# Altium schematic sheet PowerDistr.SchDoc(PowerDistr)
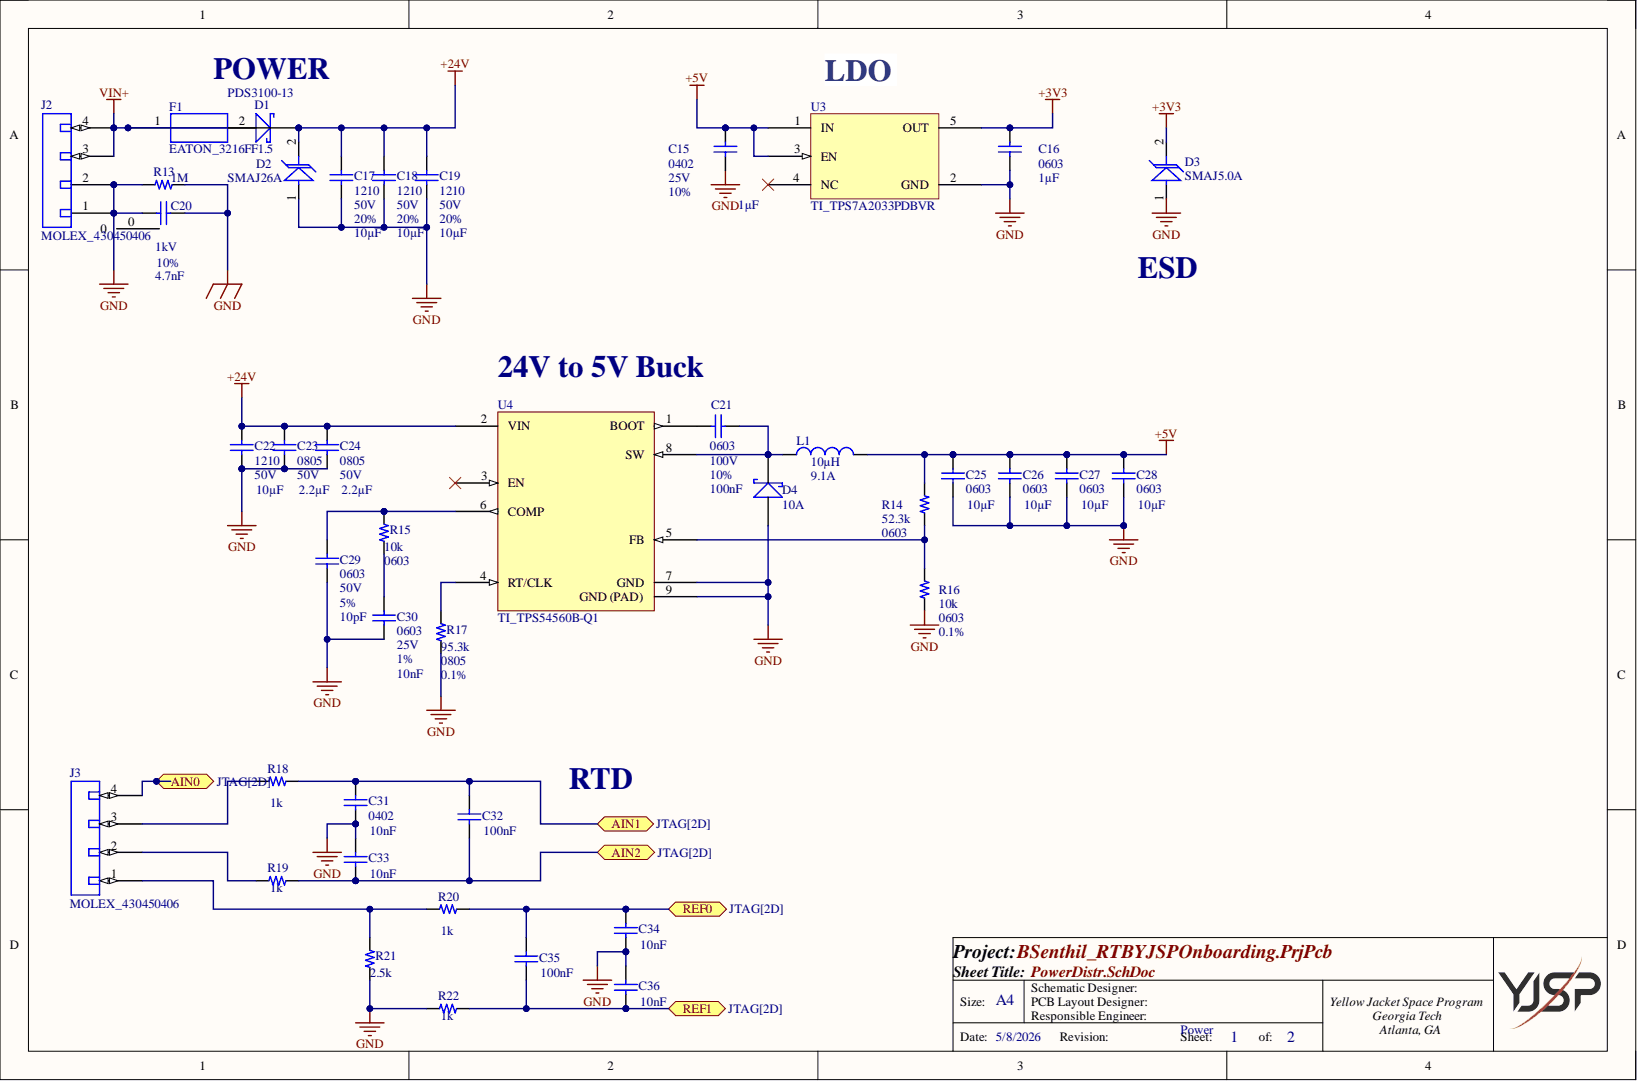
[diagram p0: PowerDistr.SchDoc]

## Parts
C15  Package: 0402  Tolerance: 10%  Voltage Rating: 25V  (hidden comment: GRM155C81E105KE11D)
C16  Capacitance: 1µF  Package: 0603  (hidden comment: CGA3E1X7R1E105M080AE)
C17  Package: 1210  Tolerance: 20%  Voltage Rating: 50V  (hidden comment: C3225X7R1H106M250AC)
C18  Package: 1210  Tolerance: 20%  Voltage Rating: 50V  (hidden comment: C3225X7R1H106M250AC)
C19  Package: 1210  Tolerance: 20%  Voltage Rating: 50V  (hidden comment: C3225X7R1H106M250AC)
C20  0805Y1K00472KST  (value not drawn)
C21  Package: 0603  (hidden comment: CL10B104KC8NNNC)
C22  Capacitance: 10µF  Package: 1210  Voltage Rating: 50V  (hidden comment: C3225X7R1H106M250AC)
C23  Capacitance: 2.2µF  Package: 0805  Voltage Rating: 50V  (hidden comment: CL21A225KBFNNNE)
C24  Capacitance: 2.2µF  Package: 0805  Voltage Rating: 50V  (hidden comment: CL21A225KBFNNNE)
C25  Capacitance: 10µF  Package: 0603  (hidden comment: GRM188R61E106MA73D)
C26  Capacitance: 10µF  Package: 0603  (hidden comment: GRM188R61E106MA73D)
C27  Capacitance: 10µF  Package: 0603  (hidden comment: GRM188R61E106MA73D)
C28  Capacitance: 10µF  Package: 0603  (hidden comment: GRM188R61E106MA73D)
C29  Package: 0603  Tolerance: 5%  Voltage Rating: 50V  (hidden comment: GRM1885C1H100JA01D)
C30  Package: 0603  Tolerance: 1%  Voltage Rating: 25V  (hidden comment: GRT1885C1E103FA02D)
C31  Capacitance: 10nF  Package: 0402  (hidden comment: GCM155R71H103KA55D)
C32  Capacitance: 100nF  (hidden comment: GCM155R71H104KE02J)
C33  Capacitance: 10nF  (hidden comment: GCM155R71H103KA55D)
C34  Capacitance: 10nF  (hidden comment: GCM155R71H103KA55D)
C35  Capacitance: 100nF  (hidden comment: GCM155R71H104KE02J)
C36  Capacitance: 10nF  (hidden comment: GCM155R71H103KA55D)
D1  PDS3100-13
D2  SMAJ26A
D3  SMAJ5.0A
D4  10A
F1  EATON_3216FF1.5
J2  MOLEX_430450406
J3  MOLEX_430450406
L1  Current Rating: 9.1A  Inductance: 10µH
R13  Resistance: 1M
R14  Package: 0603  Resistance: 52.3k
R15  Package: 0603  Resistance: 10k
R16  Package: 0603  Resistance: 10k  Tolerance: 0.1%
R17  Package: 0805  Resistance: 95.3k  Tolerance: 0.1%
R18  Resistance: 1k
R19  Resistance: 1k
R20  Resistance: 1k
R21  Resistance: 2.5k
R22  Resistance: 1k
U3  TI_TPS7A2033PDBVR
U4  TI_TPS54560B-Q1

## Ports
AIN0, AIN1, AIN2, REF0, REF1

## Nets
+3V3: C16.2 D3.2 U3.5(OUT)
+5V: C15.2 C25.2 C26.2 C27.2 C28.2 L1.2 R14.2 U3.1(IN) U3.3(EN)
+24V: C17.2 C18.2 C19.2 C22.2 C23.2 C24.2 D1.2 D2.2 U4.2(VIN)
GND: C15.1 C16.1 C17.1 C18.1 C19.1 C20.1 C20.2 C22.1 C23.1 C24.1 C25.1 C26.1 C27.1 C28.1 C29.1 C30.1 C31.1 C33.2 C34.1 C36.2 D2.1 D3.1 D4.1 J2.1 J2.2 R13.1 R13.2 R16.1 R17.1 R21.1 R22.1 U3.2(GND) U4.7(GND) U4.9(GND_(PAD))
VIN+: D1.1 F1.1 J2.3 J2.4
AIN0: J3.4 ; port AIN0
AIN1: C31.2 C32.2 R18.2 ; port AIN1
AIN2: C32.1 C33.1 R19.2 ; port AIN2
REF0: C34.2 C35.2 R20.2 ; port REF0
REF1: C35.1 C36.1 R22.2 ; port REF1
NetC21_1: C21.1 U4.1(BOOT)
NetC21_2: C21.2 D4.2 L1.1 U4.8(SW)
NetC29_2: C29.2 R15.2 U4.6(COMP)
NetC30_2: C30.2 R15.1
NetJ3_1: J3.1 R20.1 R21.2
NetJ3_2: J3.2 R19.1
NetJ3_3: J3.3 R18.1
NetR14_1: R14.1 R16.2 U4.5(FB)
NetR17_2: R17.2 U4.4(RT/CLK)

Not connected: F1 2; J2 0; U3 4(NC); U4 3(EN)

## Library data of the parts
C15 = CMP-101-0005-5: 1uF 0402 10% 25V X6S; Manufacturer: Murata; Capacitance: 1µF; Component Kind: Standard; Dielectric: X6S; Library Name: Yellow Jacket Space Program
C16 = CMP-001-00053-6: 1uF 0603 20% 25V X7R; Manufacturer: Murata; Component Kind: Standard; Dielectric: X7R; Library Name: Yellow Jacket Space Program
C17-C19 = CMP-001-00005-7: 10uF 1210 20% 50V X7R; Manufacturer: TDK; Capacitance: 10µF; Component Kind: Standard; Dielectric: X7R; Library Name: Yellow Jacket Space Program
C20 = CMP-001-00039-8: 4.7nF 0805 10% 1kV X7R; Manufacturer: Knowles; Capacitance: 4.7nF; Component Kind: Standard; Dielectric: X7R; Tolerance: 10%; Voltage Rating: 1kV; Library Name: Yellow Jacket Space Program
C21 = CMP-101-0068-1: 100nF 0603 10% 100V X7R; Manufacturer: Samsung; Capacitance: 100nF; Component Kind: Standard; Dielectric: X7R; Tolerance: 10%; Voltage Rating: 100V; Library Name: Yellow Jacket Space Program
C22 = CMP-001-00005-7: 10uF 1210 20% 50V X7R; Manufacturer: TDK; Component Kind: Standard; Dielectric: X7R; Library Name: Yellow Jacket Space Program
C23 C24 = CMP-001-00038-8: 2.2uF 0805 10% 50V X5R; Manufacturer: Samsung; Component Kind: Standard; Dielectric: X5R; Library Name: Yellow Jacket Space Program
C25-C28 = CMP-001-00065-9: 10uF 0603 20% 25V X7R; Manufacturer: Murata; Dielectric: X5R; Component Kind: Standard; Library Name: Yellow Jacket Space Program
C29 = CMP-002-000023-5: 10pF 0603 5% 50V C0G; Manufacturer: Murata; Capacitance: 10pF; Component Kind: Standard; Dielectric: C0G; Library Name: Yellow Jacket Space Program
C30 = CMP-101-0000-2: 10nF 0603 1% 25V C0G; Manufacturer: Murata; Capacitance: 10nF; Component Kind: Standard; Dielectric: C0G; Library Name: Yellow Jacket Space Program
C31 C33 C34 C36 = CMP-001-00061-16: 10nF 0402 10% 50V X7R; Manufacturer: Murata; Component Kind: Standard; Dielectric: X7R; Library Name: Yellow Jacket Space Program
C32 C35 = CMP-001-00060-16: 100nF 0402 10% 50V X7R; Manufacturer: Murata; Component Kind: Standard; Dielectric: X7R; Library Name: Yellow Jacket Space Program
D1 = CMP-102-0017-1: Diode 100 V 3A Surface Mount PowerDI™ 5; Manufacturer: Diodes; Forward Voltage (Vf): 710mV; Average Rectified Current (Io): 3A; Component Kind: Standard; Library Name: Yellow Jacket Space Program
D2 = CMP-004-00036-1: TVS DIODE UNI 26VWM 42.1VC 400W; Manufacturer: Littlefuse; Breakdown Voltage Max: 31.9; Breakdown Voltage Min: 28.9; Maximum Clamping Voltage: 42.1; Peak Pulse Power (W): 400; Reverse Standoff Voltage: 26; Component Kind: Standard; Library Name: Yellow Jacket Space Program
D3 = CMP-004-00034-1: TVS DIODE UNI 5VWM 9.2VC 400W; Manufacturer: Littlefuse; Breakdown Voltage Max: 7; Breakdown Voltage Min: 6.4; Maximum Clamping Voltage: 9.2; Peak Pulse Power (W): 400; Reverse Standoff Voltage: 5; Component Kind: Standard; Library Name: Yellow Jacket Space Program
D4 = CMP-004-00023-6: DIODE SCHOTTKY 40V 500MA SOD323F; Element Configuration: Single; Forward Voltage: 470mV; Max Reverse Current: 100uA; Average Rectified Current: 500mA; Component Kind: Standard; Forward Current: 500mA; Lead Free: Lead Free; Max Forward Surge Current (Ifsm): 10A; Max Operating Temperature: 150°C; Max Repetitive Reverse Voltage (Vrrm): 40V; Max Reverse Leakage Current: 100uA; Max Reverse Voltage (DC): 40V; Max Surge Current: 10A; Min Operating Temperature: -65°C; Mount: Surface Mount; Number of Pins: 2; Package Quantity: 1; Packaging: Cut Tape; Peak Non-Repetitive Surge Current: 10A; Radiation Hardening: No; Reach SVHC Compliant: Yes; Series: -; Voltage: 40V; Library Name: Yellow Jacket Space Program
F1 = CMP-005-00000-2: 1.5A 32V AC 63V DC Fuse Board Mount (Cartridge Style Excluded) Surface Mount 1206 (3216 Metric); Resistance: 119mR; Case/Package: 1206; Component Kind: Standard; Current Rating: 1.5A; Fuse Current: 1.5A; Fuse Type: Board Mount (Cartridge Style Excluded); Height: 0.89mm; Lead Free: Lead Free; Length: 3.2mm; Max Operating Temperature: 125°C; Max Voltage Rating (AC): 32V; Max Voltage Rating (DC): 63V; Min Operating Temperature: -65°C; Mount: Surface Mount; Number of Pins: 2; Package Quantity: 3000; Packaging: Tape and Reel; Radiation Hardening: No; REACH SVHC: No SVHC; Voltage Rating (AC): 32V; Voltage Rating (DC): 63V; Weight: 0.016oz; Width: 1.6mm; Library Name: Yellow Jacket Space Program
J2 J3 = CMP-002-00013-2: CONN HEADER SMD R/A 4POS 3MM; Contact Resistance: 10mR; Current Rating: 5A; Gender: Male; Glow Wire Compliant: Compliant; Max Voltage Rating (DC): 250.25kV; Number of Circuits: 4; Voltage Rating: 600V; Voltage Rating (DC): 250V; Case/Package: 0406; Color: Black; Component Kind: Standard; Contact Material: Brass; Contact Plating: Tin; Flammability Rating: UL94 V-0; Halogen Free: Low Halogen; Housing Material: Thermoplastic; Max Operating Temperature: 105°C; Min Operating Temperature: -40°C; Mount: Surface Mount; Number of Contacts: 4; Number of Positions: 4; Number of Rows: 2; Orientation: Right Angle; Package Quantity: 1800; Packaging: Tape and Reel; Pitch: 3mm; REACH SVHC: Unknown; Row Spacing: 3mm; Termination: SMD/SMT; Library Name: Yellow Jacket Space Program
L1 = CMP-00063-1: 10uH SMD 20% 9.1A; Manufacturer: CoilCraft; DC Resistance: 20.4; Component Kind: Standard; Tolerance: 20%; Library Name: Yellow Jacket Space Program
R13 = CMP-009-00030-15: 1M 0805 1% 1/2W; Mfr_Name: Bourns; Rated Power: 500mW; Component Kind: Standard; Library Name: Yellow Jacket Space Program
R14 = CMP-100-0040-1: 52.3k 0603 0.1% 1/10W; Mfr_Name: Panasonic; Rated Power: 100mW; Component Kind: Standard; Library Name: Yellow Jacket Space Program
R15 R16 = CMP-009-00099-13: 10K 0603 0.1% 1/10W; Mfr_Name: Panasonic; Rated Power: 100mW; Component Kind: Standard; Library Name: Yellow Jacket Space Program
R17 = CMP-009-00050-16: 95.3k 0805 0.1% 1/8W; Mfr_Name: Panasonic; Rated Power: 125mW; Component Kind: Standard; Library Name: Yellow Jacket Space Program
R18-R20 R22 = CMP-100-0019-1: 1k 0402 0.1% 1/16W; Mfr_Name: Panasonic; Rated Power: 62.5mW; Component Kind: Standard; Library Name: Yellow Jacket Space Program
R21 = CMP-100-0105-2: 2.5k 0603 0.1% 1/6W; Mfr_Name: Stackpole; Rated Power: 167mW; Component Kind: Standard; Library Name: Yellow Jacket Space Program
U3 = CMP-200-0026-5: Fixed Positive LDO Regulator, 3.3V, 0.14V Dropout, PDSO5; Manufacturer: Texas Instruments; Component Kind: Standard; Library Name: Yellow Jacket Space Program
U4 = CMP-001-0010-5: Buck, Split Rail Switching Regulator IC Positive Adjustable 0.8V 1 Output 5A 8-PowerSOIC (0.154", 3.90mm Width); Max Input Voltage: 60V; Max Output Voltage: 58.8V; Min Input Voltage: 4.5V; Min Output Voltage: 800mV; Number of Outputs: 1; Switching Frequency: 2.5MHz; Synchronous Rectifier: No; Component Kind: Standard; Max Operating Temperature: 125°C; Min Operating Temperature: -40°C; Mount: Surface Mount; Number of Pins: 8; Output Configuration: Positive; Output Current: 5A; Output Type: Adjustable; Packaging: Tape and Reel; Library Name: Yellow Jacket Space Program
